# Altium schematic sheet BootMemory.SchDoc
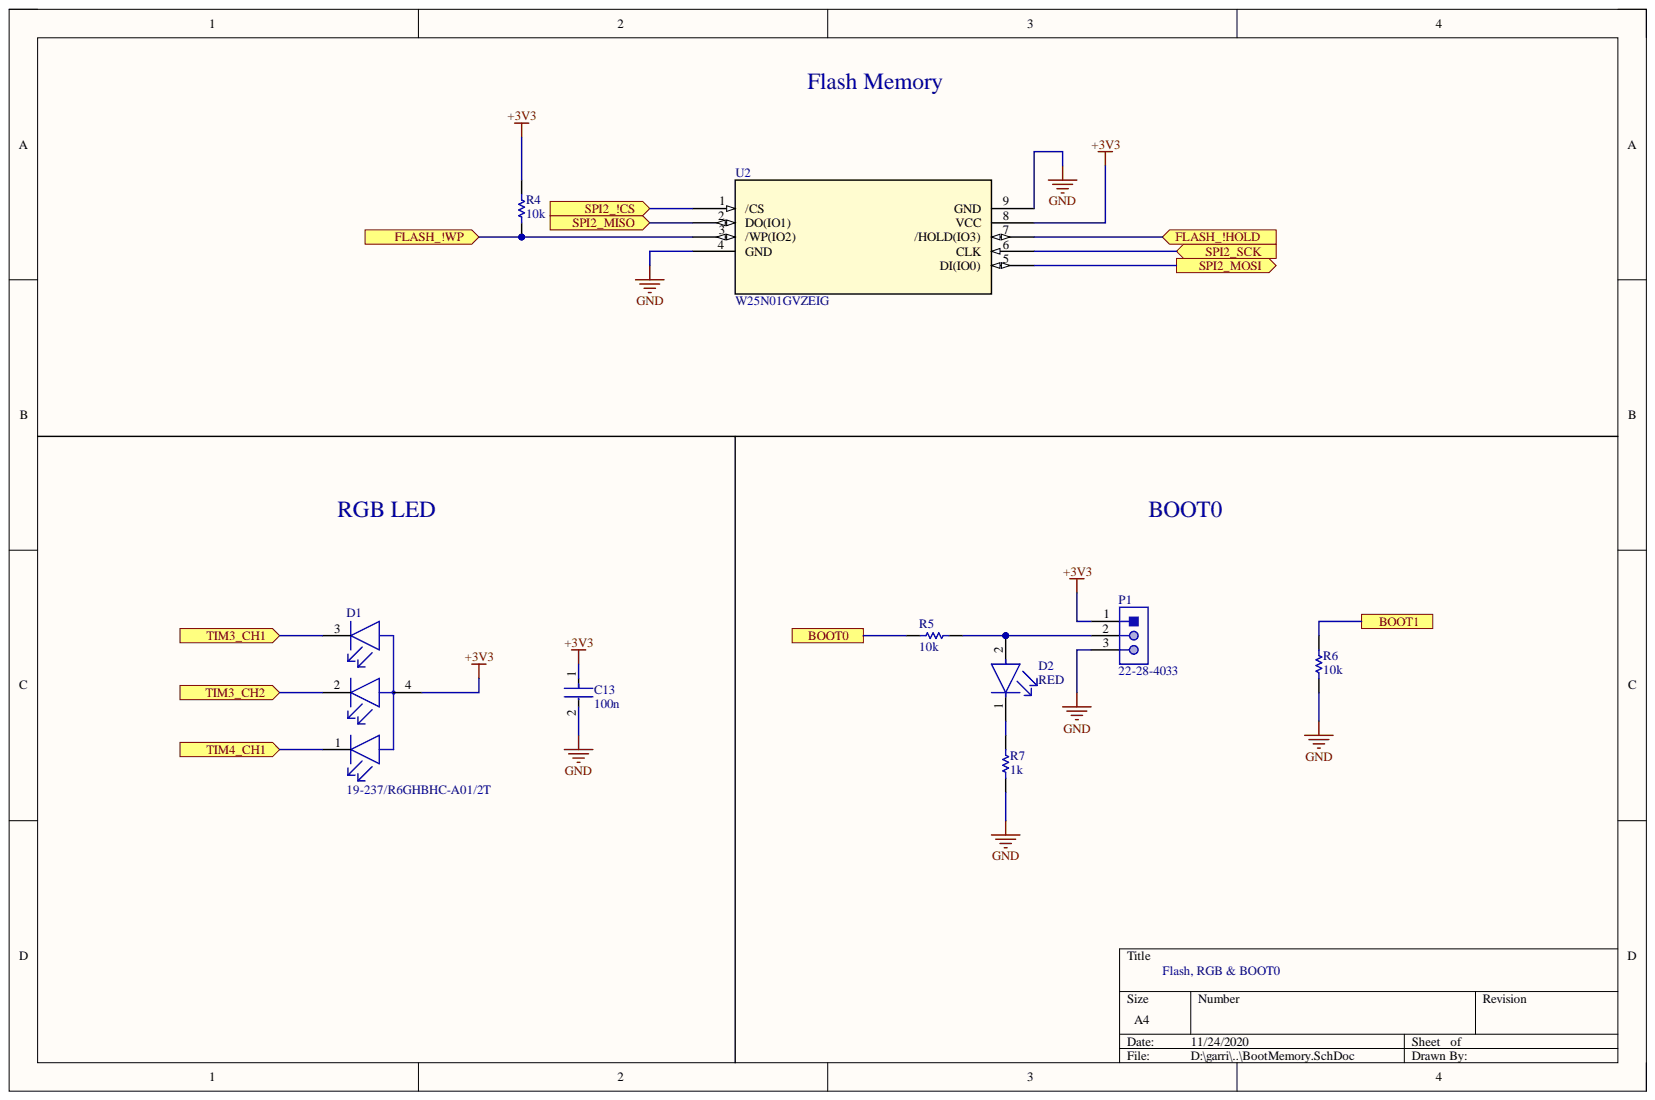
[diagram p0: BootMemory.SchDoc]

## Parts
C13  100n  [Capacitor 0402]
D1  19-237/R6GHBHC-A01/2T  [19337R6GHBHCA012T]
D2  RED  [FP-LTST-C190GKT-MFG]
P1  22-28-4033  [HDRV10W90P254_1X3_1270X483X840P]
R4  10k  [Resistor 0402]
R5  10k  [Resistor 0402]
R6  10k  [Resistor 0402]
R7  1k  [Resistor 0402]
U2  W25N01GVZEIG  [SON127P800X600X80-9N-D]

## Ports
BOOT0, BOOT1, FLASH_!HOLD, FLASH_!WP, SPI2_!CS, SPI2_MISO, SPI2_MOSI, SPI2_SCK, TIM3_CH1, TIM3_CH2, TIM4_CH1

## Nets
+3V3: C13.1 D1.4 P1.1 R4.2 U2.8(VCC)
GND: C13.2 P1.3 R6.2 R7.2 U2.4(GND) U2.9(GND)
BOOT0: R5.2 ; port BOOT0
BOOT1: R6.1 ; port BOOT1
FLASH_!HOLD: U2.7(/HOLD(IO3)) ; port FLASH_!HOLD
FLASH_!WP: R4.1 U2.3(/WP(IO2)) ; port FLASH_!WP
SPI2_!CS: U2.1(/CS) ; port SPI2_!CS
SPI2_MISO: U2.2(DO(IO1)) ; port SPI2_MISO
SPI2_MOSI: U2.5(DI(IO0)) ; port SPI2_MOSI
SPI2_SCK: U2.6(CLK) ; port SPI2_SCK
TIM3_CH1: D1.3 ; port TIM3_CH1
TIM3_CH2: D1.2 ; port TIM3_CH2
TIM4_CH1: D1.1 ; port TIM4_CH1
NetD2_1: D2.1 R7.1
NetD2_2: D2.2 P1.2 R5.1

## Library data of the parts
C13 = Capacitor 0402: Chip Capacitor 0402; Manufacturer: Yageo; Manufacturer Part Number: CC0402KRX7R7BB103; Automotive Grade: NA; Capacitance: 10 nF; Material: Ceramic; Temperature Characteristic: X7R; Temperature Coefficient: 30ppm/°C; Tolerance: 0.1; Value: 10 nF; Voltage Rating: 16 V; Automotive: No; Category: Cap; Component Kind: Standard; ComponentLink2Description: Datasheet; ComponentLink2URL: http://www.yageo.com/documents/recent/UPY-GP_NP0_16V-to-50V_16.pdf; Device Class L1: Passive Components; Device Class L2: Capacitors; Device Class L3: Ceramic Capacitors; Digikey Description: CAP CER 10000PF 16V X7R 0402; Footprint Url: http://www.yageo.com/exep/pages/download/literatures/UPY-C_GEN_24.pdf; Height: 0.55mm; Ipc Land Pattern Name: CAPC10050X50; Lead Free: Yes; Library Name: F4_Flight_Controller.SCHLIB; Mouser Description: 0402 10 nF 16 V ±10 % Tolerance X7R SMT Multilayer Ceramic Capacitor; Octopart: https://octopart.com/cc0402krx7r7bb103-yageo-192895; Package: CC0402; RoHS: TRUE; Temperature: -55°C to +125°C; Temperature Range High: +125°C; Temperature Range Low: -55°C
D1 = LED RGB: LED RGB CLEAR CHIP SMD R/A; Manufacturer: Everlight; Manufacturer Part Number: 12-23A/R6GHBHC-A01/2D; Colour: Red, Green, Blue; Forward Current: 20mA; Forward Voltage: 2/3.3/3.3V; Led Orientation: Side View; Luminous Intensity: 90/180/72mcd; Peak Emmision Wavelength: 632/518/468nm; Power Dissipation: 60/110/110mW; Reverse Voltage: 5V; Viewing Angle: 120°; Wavelength: 624/525/470nm; Automotive: No; Category: Diode; Component Kind: Standard; ComponentLink2Description: Datasheet; ComponentLink2URL: https://media.digikey.com/pdf/Data%20Sheets/Everlight%20PDFs/12-23A,R6GHBHC-A01,2D.pdf; Device Class L1: Optoelectronics; Device Class L2: LEDs; Device Class L3: unset; Digikey Description: LED RGB CLEAR CHIP SMD R/A; Height: 2.1mm; Lead Free: Yes; Library Name: F4_Flight_Controller.SCHLIB; Mouser Description: Standard LEDs - SMD RGB WATER CLEAR RIGHT ANGLE LENS; Octopart: https://octopart.com/12-23a%2Fr6ghbhc-a01%2F2d-everlight-10561613; Package: 12-23A; RoHS: TRUE; Temperature: -40°C to +85°C; Temperature Range High: +85°C; Temperature Range Low: -40°C
D2 = CMP-22017-000005-1: LED GREEN CLEAR CHIP SMD; Dominant Wavelength: 569nm; Forward Current: 30mA; Forward Voltage: 2.1V; Lens Color: Colorless; Luminous Intensity: 6mcd; Number of Elements: 1; Power Dissipation: 100mW; Reverse Voltage: 5V; Test Current: 10mA; Viewing Angle: 130°; Voltage: 2V; Wavelength: 565nm; Case Code (Imperial): 0603; Case Code (Metric): 1608; Case/Package: 0603; Color: Green; Component Kind: Standard; Depth: 0.8mm; Height: 0.8mm; Illumination Color: Green; Lead Free: Lead Free; Length: 1.6mm; Lens Style: Clear; Lens Transparency: Clear; Library Name: Altium Content Vault; Max Operating Temperature: 85°C; Min Operating Temperature: -55°C; Mount: Surface Mount; Number of LEDs: 1; Number of Pins: 2; Package Quantity: 1; Packaging: Tape and Reel; Power Rating: 100mW; Radiation Hardening: No; Width: 0.8mm
P1 = Male Header 01x03: Male Header, Pitch 2.54 mm, 1 x 3 Position, Height 8.38 mm, Tail Length 3.18 mm, RoHS, Bulk; Manufacturer: Molex; Current Rating: 4 A; Rows: 1; Voltage Rating: 250 V; Colour: Black; Component Kind: Standard; ComponentLink1Description: Manufacturer URL; ComponentLink1URL: http://www.molex.com/; ComponentLink2Description: Datasheet; ComponentLink2URL: http://www.molex.com/pdm_docs/sd/022284033_sd.pdf; Contact Finish: Gold; Contact Material: Brass; Contact Plating: Gold; DatasheetVersion: Rev. G, 01/2012; Height: 8.38 mm; Lead Pitch: 0.1 inch; Length: 7.62 mm; Library Name: F4_Flight_Controller.SCHLIB; Manufacturer Part Number: 22-28-4033; Max Operating Temperature: 75 degC; Min Operating Temperature: 0 degC; Mount: Through Hole; Mounting Technology: Through Hole; Package Quantity: 1; PackageDescription: Male Header, Pitch 2.54 mm, 1 x 3 Position, Height 8.38 mm, Tail Length 3.18 mm; PackageReference: 22-28-4033; Packaging: Bulk; Pins: 3; REACH SVHC: Unknown; RoHSCompliant: true
R4-R7 = Resistor 0402: Chip Resistor 0402; Manufacturer: Vishay; Manufacturer Part Number: CRCW0402120RFKED; Component Kind: Standard; Library Name: F4_Flight_Controller.SCHLIB; Part Number: CRCW0402120RFKED
U2 = W25N01GVZEIG: Flash memory; Built_By: EMA_Cory; SourceLibrary: Winbond_2020-02-06; Vendor: Winbond; Component Kind: Standard; Datasheet: https://www.winbond.com/resource-files/w25n01gv%20revl%20050918%20unsecured.pdf; Library Name: F4_Flight_Controller.SCHLIB; Manufacturer_Part_Number: W25N01GVZEIG; RefDes: RefDes; Type: DEV; Copyright: Copyright (C) 2018 Accelerated Designs. All rights reserved
